# Altium schematic sheet Sheet1.SchDoc(Sheet1)
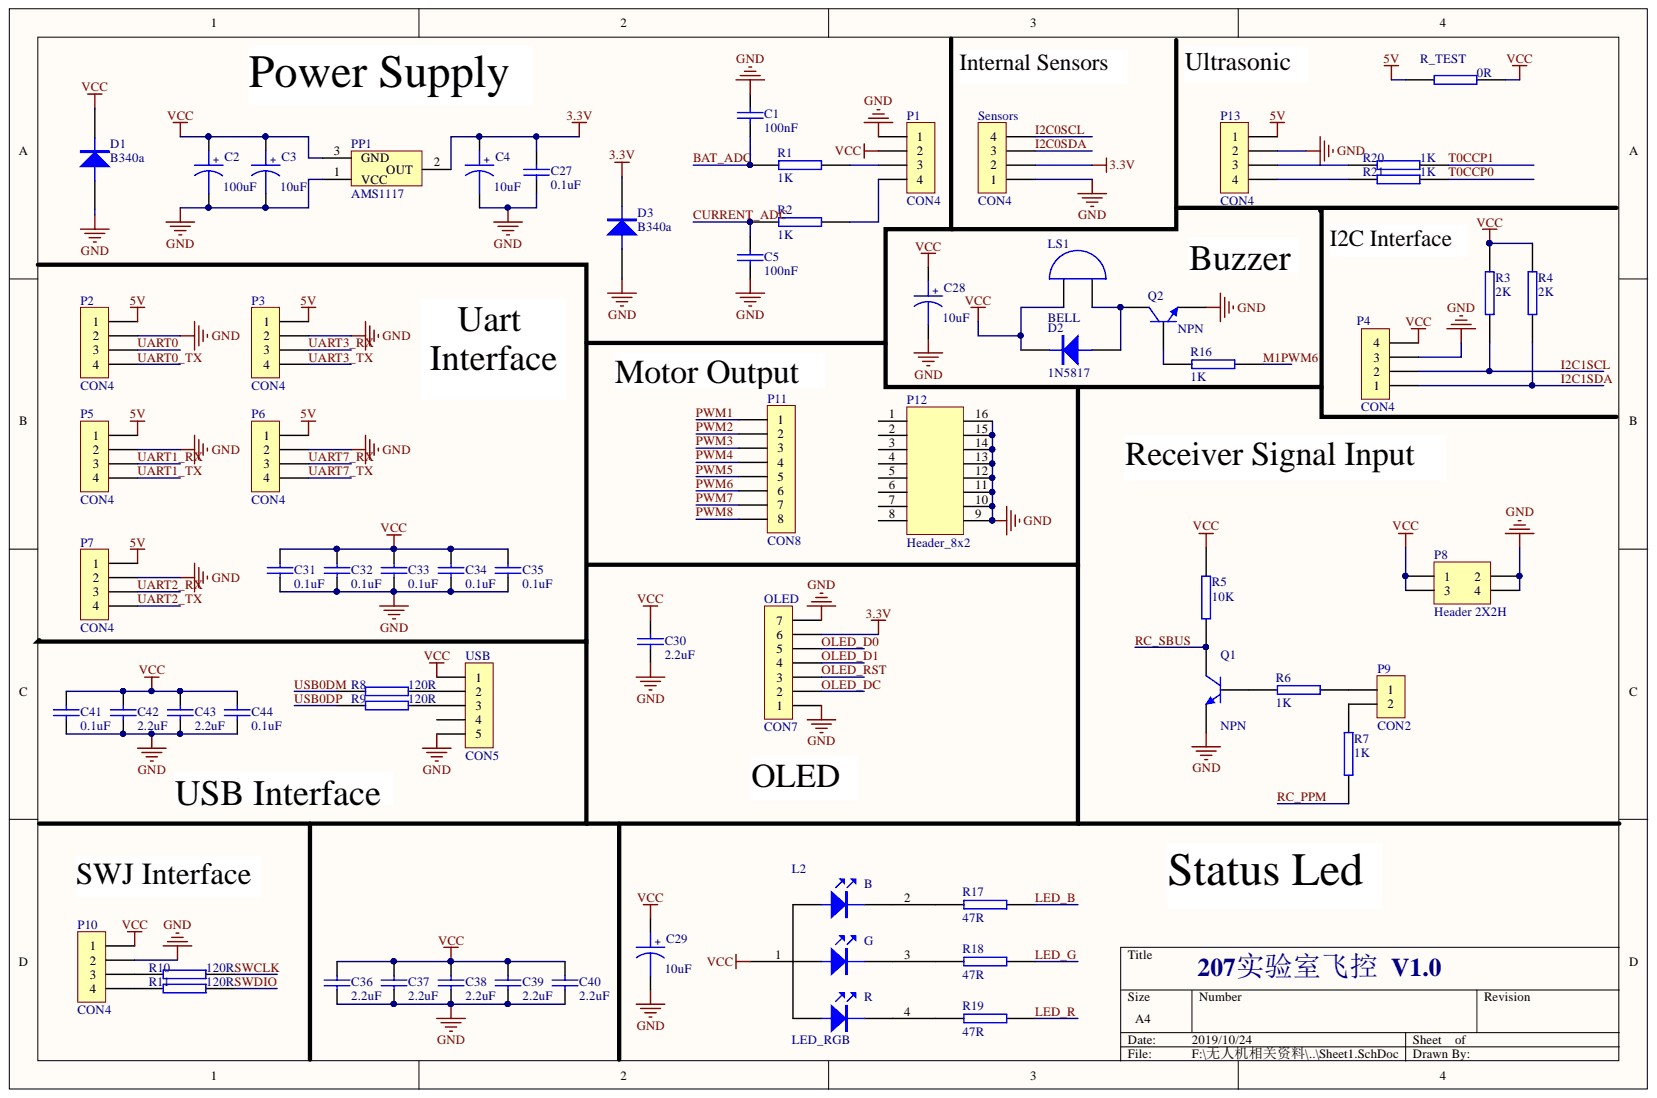
[diagram p0: Sheet1.SchDoc]

## Parts
C1  100nF  [C0603]
C2  100uF  [1206]
C3  10uF  [1206]
C4  10uF  [1206]
C5  100nF  [C0603]
C27  0.1uF  [C0603]
C28  10uF  [1206]
C29  10uF  [1206]
C30  2.2uF  [C0603]
C31  0.1uF  [C0603]
C32  0.1uF  [C0603]
C33  0.1uF  [C0603]
C34  0.1uF  [C0603]
C35  0.1uF  [C0603]
C36  2.2uF  [C0603]
C37  2.2uF  [C0603]
C38  2.2uF  [C0603]
C39  2.2uF  [C0603]
C40  2.2uF  [C0603]
C41  0.1uF  [C0603]
C42  2.2uF  [C0603]
C43  2.2uF  [C0603]
C44  0.1uF  [C0603]
D1  B340a  [SOD123]
D2  1N5817  [SOD123]
D3  B340a  [SOD123]
L2  LED_RGB  [RGB_LED]
LS1  BELL  [BEEP_TIE]
OLED  CON7  [HDR1X7]
P1  CON4  [HDR1X4]
P2  CON4  [GH1.25]
P3  CON4  [GH1.25]
P4  CON4  [GH1.25]
P5  CON4  [GH1.25]
P6  CON4  [GH1.25]
P7  CON4  [GH1.25]
P8  Header 2X2H  [HDR2X2]
P9  CON2  [HDR1X2]
P10  CON4  [GH1.25]
P11  CON8  [HDR1X8]
P12  Header_8x2  [H8x2]
P13  CON4  [GH1.25]
PP1  AMS1117  [SOT-223]
Q1  NPN  [SOT-23]
Q2  NPN  [SOT-23]
R1  1K  [R0603]
R2  1K  [R0603]
R3  2K  [R0603]
R4  2K  [R0603]
R5  10K  [R0603]
R6  1K  [R0603]
R7  1K  [R0603]
R8  120R  [R0603]
R9  120R  [R0603]
R10  120R  [R0603]
R11  120R  [R0603]
R16  1K  [R0603]
R17  47R  [R0603]
R18  47R  [R0603]
R19  47R  [R0603]
R20  1K  [R0603]
R21  1K  [R0603]
R_TEST  0R  [R0603]
Sensors  CON4  [GH1.25]
USB  CON5  [USB_MINI]

## Nets
3.3V: C4.1 C27.1 D3.2 OLED.6 PP1.2 Sensors.2
5V: P2.1 P3.1 P5.1 P6.1 P7.1 P13.1 R_TEST.1
GND: C1.1 C2.2 C3.2 C4.2 C5.2 C27.2 C28.2 C29.2 C30.2 C31.2 C32.2 C33.2 C34.2 C35.2 C36.2 C37.2 C38.2 C39.2 C40.2 C41.2 C42.2 C43.2 C44.2 D1.1 D3.1 OLED.1 OLED.7 P1.1 P2.2 P3.2 P4.3 P5.2 P6.2 P7.2 P8.2 P8.4 P10.2 P12.9 P12.10 P12.11 P12.12 P12.13 P12.14 P12.15 P12.16 P13.2 PP1.1 Q1.1 Q2.1 Sensors.1 USB.5
VCC: C2.1 C3.1 C28.1 C29.1 C30.1 C31.1 C32.1 C33.1 C34.1 C35.1 C36.1 C37.1 C38.1 C39.1 C40.1 C41.1 C42.1 C43.1 C44.1 D1.2 D2.2 L2.1 LS1.1 P1.2 P4.4 P8.1 P8.3 P10.1 PP1.3 R3.2 R4.2 R5.1 R_TEST.2 USB.1
BAT_ADC: C1.2 R1.1
CURRENT_ADC: C5.1 R2.1
I2C0SCL: Sensors.4
I2C0SDA: Sensors.3
I2C1SCL: P4.2 R3.1
I2C1SDA: P4.1 R4.1
LED_B: R17.1
LED_G: R18.1
LED_R: R19.1
M1PWM6: R16.1
OLED_D0: OLED.5
OLED_D1: OLED.4
OLED_DC: OLED.2
OLED_RST: OLED.3
PWM1: P11.1
PWM2: P11.2
PWM3: P11.3
PWM4: P11.4
PWM5: P11.5
PWM6: P11.6
PWM7: P11.7
PWM8: P11.8
RC_PPM: R7.1
RC_SBUS: Q1.3 R5.2
SWCLK: R10.2
SWDIO: R11.2
T0CCP0: R21.2
T0CCP1: R20.2
UART0: P2.3
UART0_TX: P2.4
UART1_RX: P5.3
UART1_TX: P5.4
UART2_RX: P7.3
UART2_TX: P7.4
UART3_RX: P3.3
UART3_TX: P3.4
UART7_RX: P6.3
UART7_TX: P6.4
USB0DM: R8.1
USB0DP: R9.1
NetD2_1: D2.1 LS1.2 Q2.3
NetL2_2: L2.2 R17.2
NetL2_3: L2.3 R18.2
NetL2_4: L2.4 R19.2
NetP1_3: P1.3 R1.2
NetP1_4: P1.4 R2.2
NetP9_1: P9.1 R6.2
NetP9_2: P9.2 R7.2
NetP10_3: P10.3 R10.1
NetP10_4: P10.4 R11.1
NetP13_3: P13.3 R20.1
NetP13_4: P13.4 R21.1
NetQ1_2: Q1.2 R6.1
NetQ2_2: Q2.2 R16.2
NetR8_2: R8.2 USB.2
NetR9_2: R9.2 USB.3

Not connected: L2 A K; P12 1 2 3 4 5 6 7 8; USB 4

## Library data of the parts
C1 C5 C27 C30-C44 = CAP: Capacitor; Component Kind: Standard; Library Name: Backup of Miscellaneous Devices.lib
C2-C4 C28 C29 = CAPACITOR POL: Capacitor; Component Kind: Standard; Library Name: Backup of Miscellaneous Devices.lib
D1-D3 = DIODE: Component Kind: Standard; Description: Diode; Library Name: Backup of Miscellaneous Devices.lib
OLED = CON7: Connector; Component Kind: Standard; Library Name: Backup of Miscellaneous Devices.lib
P1-P7 P10 P13 Sensors = CON4: Connector; Component Kind: Standard; Library Name: Backup of Miscellaneous Devices.lib
P8 = Header 2X2H: Header, 2-Pin, Dual row, Right Angle; Component Kind: Standard; LatestRevisionDate: 17-Jul-2002; LatestRevisionNote: Re-released for DXP Platform.; Library Name: Miscellaneous Connectors.IntLib; Signal Integrity: Connector; Publisher: Altium Limited
P9 = CON2: Connector; Component Kind: Standard; Library Name: Backup of Miscellaneous Devices.lib
P11 = CON8: Connector; Component Kind: Standard; Library Name: Backup of Miscellaneous Devices.lib
PP1 = 12M: Component Kind: Standard; Library Name: Backup of Miscellaneous Devices.lib
Q1 Q2 = 2N3904: NPN General Purpose Amplifier; Component Kind: Standard; ComponentLink1Description: Manufacturer Link; ComponentLink1URL: http://www.fairchildsemi.com/; ComponentLink2Description: Datasheet; ComponentLink2URL: http://www.fairchildsemi.com/ds/2N%2F2N3904.pdf; DatasheetVersion: 1997; LatestRevisionDate: 15-Jan-2003; LatestRevisionNote: PCB Footprint 'BCY-W3/D4.7' replaced; Library Name: Miscellaneous Devices.IntLib; PackageDescription: TO, Flat Index; 3 In-Line, Axial Leads; Body Dia. 4.5mm; Leads 0.51 x 0.48 mm (max); PackageReference: TO-92A; PackageVersion: Sep-1998; Published: 8-Jun-2000; Signal Integrity: 2N3904; Simulation: 2N3904; Publisher: Altium Limited
R1-R11 R16-R21 R_TEST = RES2: Component Kind: Standard; Library Name: Backup of Miscellaneous Devices.lib
USB = CON5: Connector; Component Kind: Standard; Library Name: Backup of Miscellaneous Devices.lib
L2 = LED_RGB: Component Kind: Standard; Library Name: Backup of Miscellaneous Devices.lib
LS1 = BELL: Component Kind: Standard; Description: Bell; Library Name: Backup of Miscellaneous Devices.lib
P12 = Header_8x2: Component Kind: Standard; Library Name: Backup of Miscellaneous Devices.lib
